# Altium schematic sheet PMOD Connectors.SchDoc("PMOD Connectors")
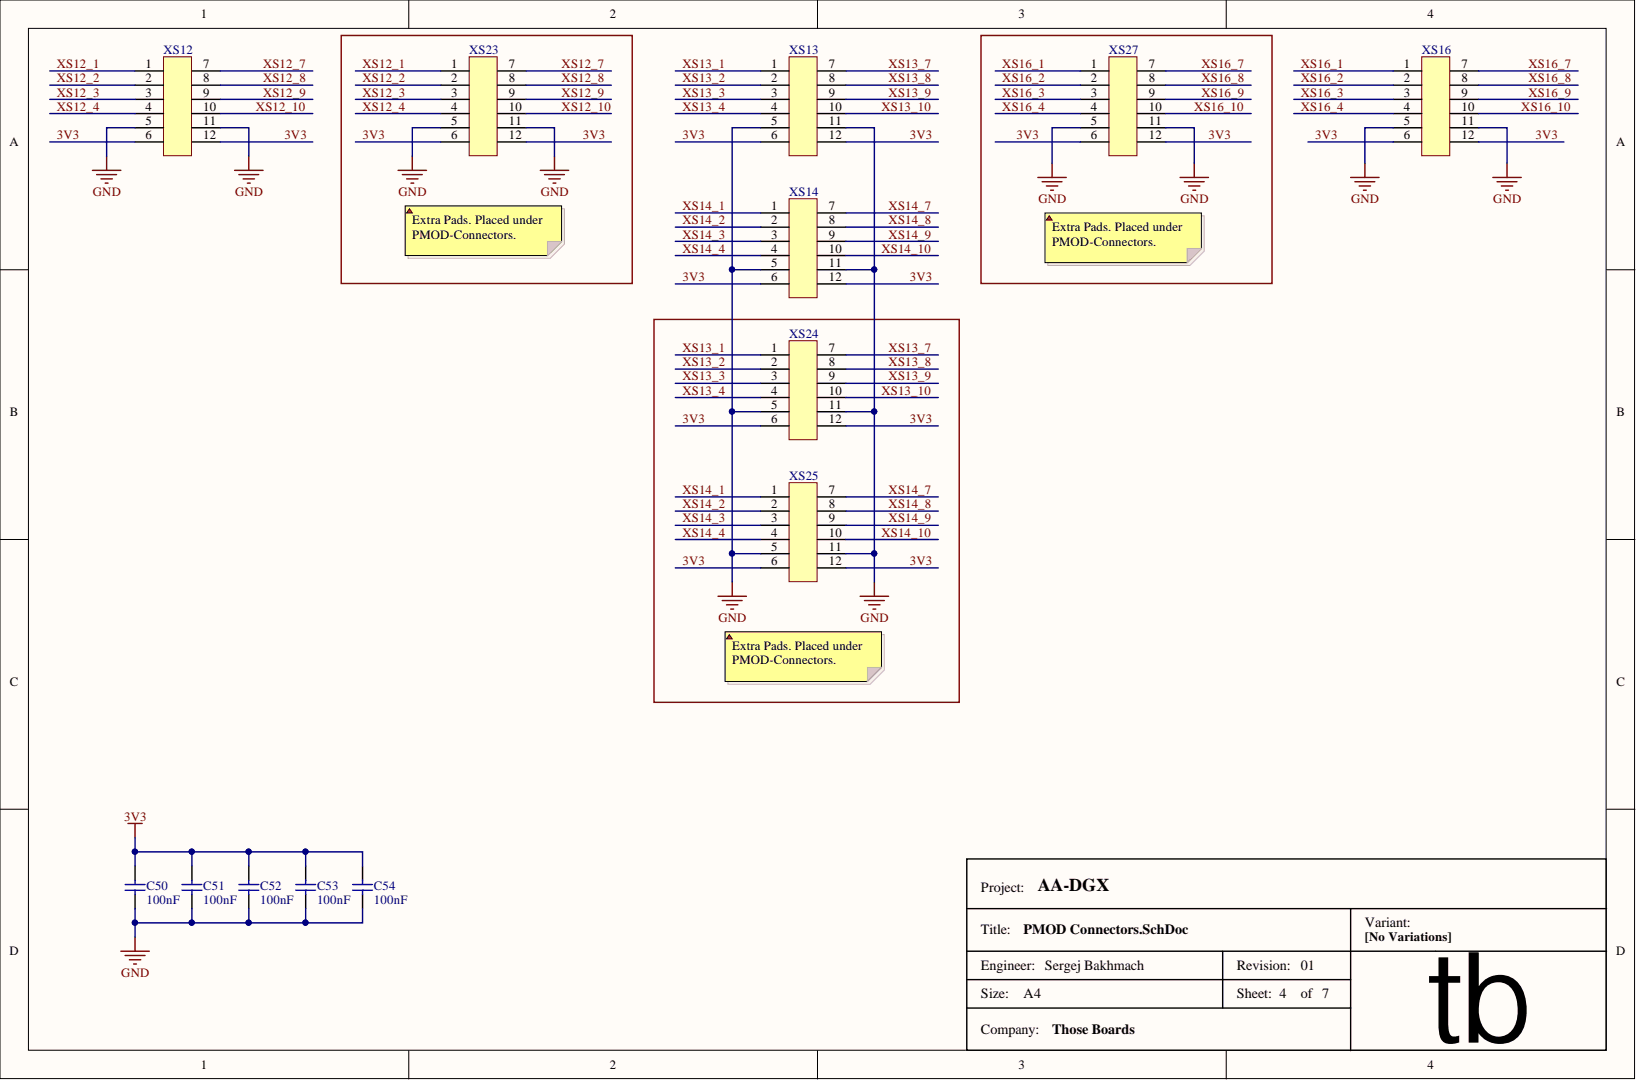
[diagram p0: PMOD Connectors.SchDoc]

## Parts
C50  value 100nF  [C0603]  (hidden comment: CAP)
C51  value 100nF  [C0603]  (hidden comment: CAP)
C52  value 100nF  [C0603]  (hidden comment: CAP)
C53  value 100nF  [C0603]  (hidden comment: CAP)
C54  value 100nF  [C0603]  (hidden comment: CAP)
XS12  DG2_connector_horizontal_f  [CONN_2DG_H_F]  (value not drawn)
XS13  DG2_connector_horizontal_f  [CONN_2DG_H_F]  (value not drawn)
XS14  DG2_connector_horizontal_f  [CONN_2DG_H_F]  (value not drawn)
XS16  DG2_connector_horizontal_f  [CONN_2DG_H_F]  (value not drawn)
XS23  Pads  [Conn_2DG]  (value not drawn)  (no BOM)
XS24  Pads  [Conn_2DG]  (value not drawn)  (no BOM)
XS25  Pads  [Conn_2DG]  (value not drawn)  (no BOM)
XS27  Pads  [Conn_2DG]  (value not drawn)  (no BOM)

## Nets
GND: C50.1 C51.1 C52.1 C53.1 C54.1 XS12.5 XS12.11 XS13.5 XS13.11 XS14.5 XS14.11 XS16.5 XS16.11 XS23.5 XS23.11 XS24.5 XS24.11 XS25.5 XS25.11 XS27.5 XS27.11
3V3: C50.2 C51.2 C52.2 C53.2 C54.2 XS12.6 XS12.12 XS13.6 XS13.12 XS14.6 XS14.12 XS16.6 XS16.12 XS23.6 XS23.12 XS24.6 XS24.12 XS25.6 XS25.12 XS27.6 XS27.12
XS12_1: XS12.1 XS23.1
XS12_2: XS12.2 XS23.2
XS12_3: XS12.3 XS23.3
XS12_4: XS12.4 XS23.4
XS12_7: XS12.7 XS23.7
XS12_8: XS12.8 XS23.8
XS12_9: XS12.9 XS23.9
XS12_10: XS12.10 XS23.10
XS13_1: XS13.1 XS24.1
XS13_2: XS13.2 XS24.2
XS13_3: XS13.3 XS24.3
XS13_4: XS13.4 XS24.4
XS13_7: XS13.7 XS24.7
XS13_8: XS13.8 XS24.8
XS13_9: XS13.9 XS24.9
XS13_10: XS13.10 XS24.10
XS14_1: XS14.1 XS25.1
XS14_2: XS14.2 XS25.2
XS14_3: XS14.3 XS25.3
XS14_4: XS14.4 XS25.4
XS14_7: XS14.7 XS25.7
XS14_8: XS14.8 XS25.8
XS14_9: XS14.9 XS25.9
XS14_10: XS14.10 XS25.10
XS16_1: XS16.1 XS27.1
XS16_2: XS16.2 XS27.2
XS16_3: XS16.3 XS27.3
XS16_4: XS16.4 XS27.4
XS16_7: XS16.7 XS27.7
XS16_8: XS16.8 XS27.8
XS16_9: XS16.9 XS27.9
XS16_10: XS16.10 XS27.10

## Library data of the parts
C50-C54 = CAP: Manufacturer: Vishay / Vitramon; Manufacturer Part: VJ0603Y104JXJPW1BC; Type of Component: Ceramic Capacitor; Component Kind: Standard; Manufacturer Link: http://www.vishay.com/product?docid=28548; Package / Case: 0603; Supplier: mouser; Supplier Link: http://www.mouser.com/ProductDetail/Vishay/VJ0603Y104JXJPW1BC/?qs=%2fha2pyFaduidGoO0X7aK7Xgs%2fjxfhnLiK6SRw4Lz0%252bZK3PJ8DddTrQ%3d%3d; Supplier Part: 77-VJ0603Y104JXJPBC; Library Name: RPI-VITA.IntLib
XS12-XS14 XS16 = DG2_connector_horizontal_f: SSHR-1-06-D; Manufacturer: HK-Ada; Manufacturer Part: PMOD 2x6 RA F; Type of Component: PMOD Right Angle Female; Value: PMOD 2x6 RA F; Component Kind: Standard; Package / Case: Header 2x6 RA; Supplier: HK-Ada; Library Name: RPI-VITA.IntLib
XS23-XS25 XS27 = DG2_connector: Library Name: RPI-VITA.IntLib
